# Altium schematic sheet Pmod_audio_in.SchDoc
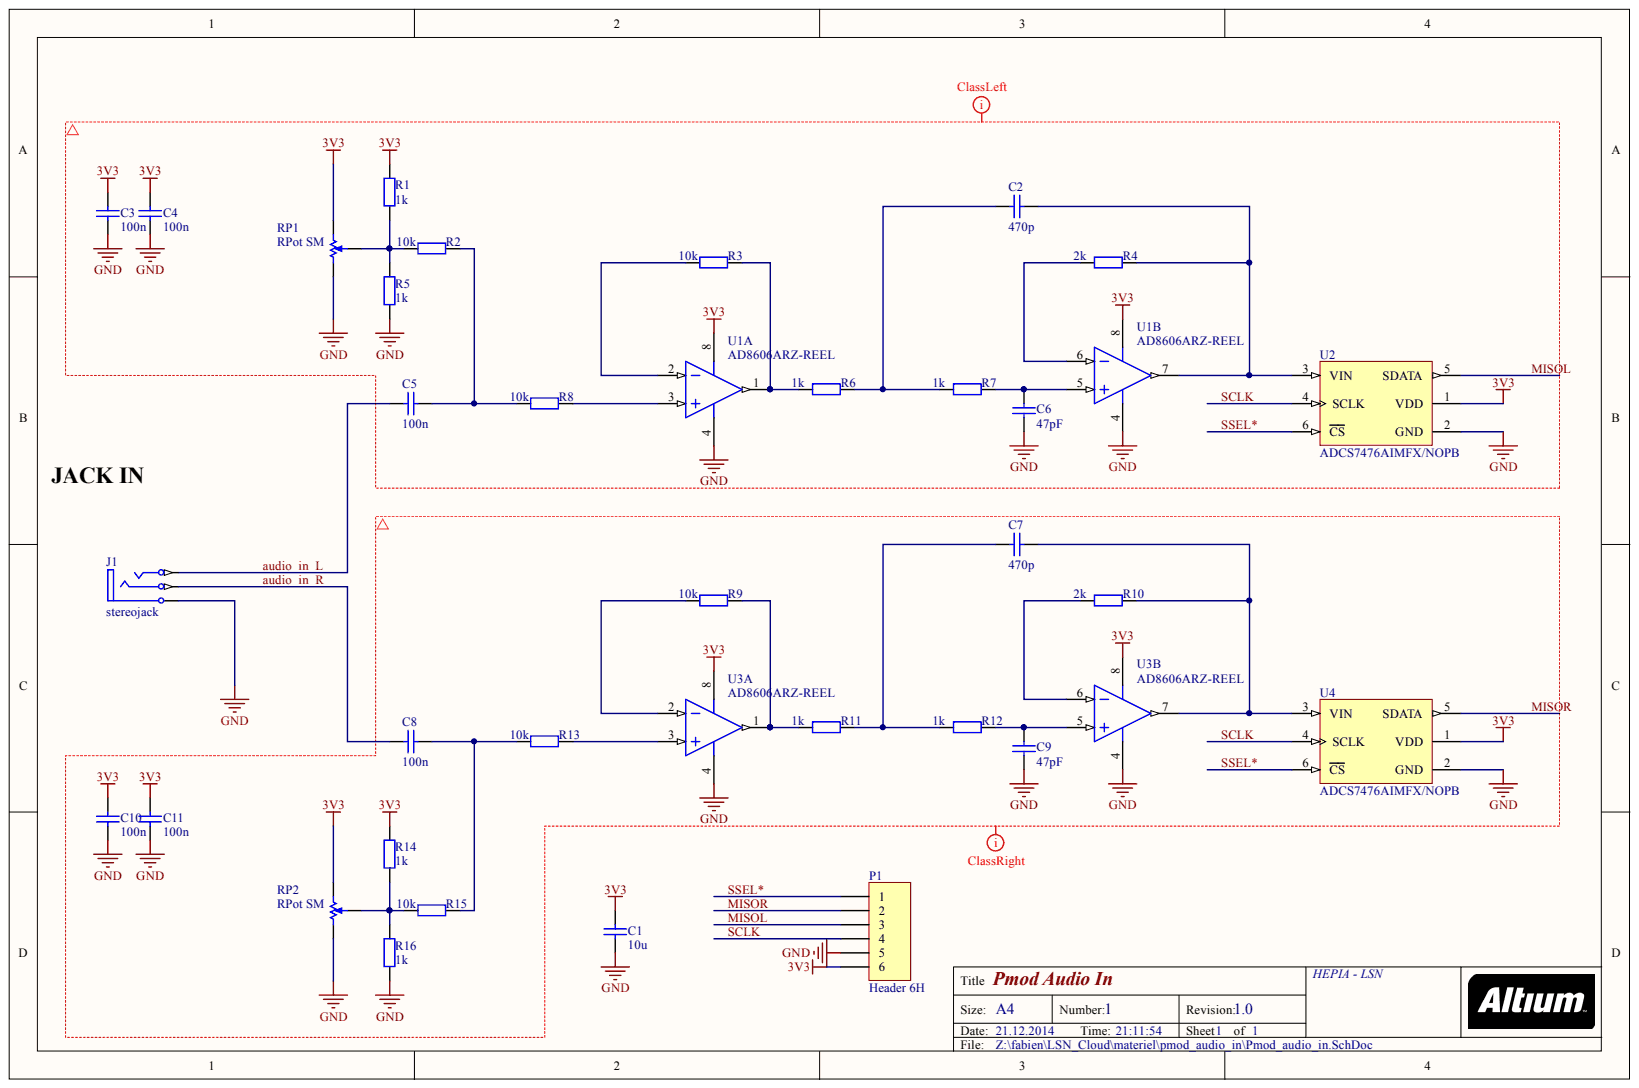
[diagram p0: Pmod_audio_in.SchDoc]

## Parts
C1  10u  [6-0805_M]
C2  470p  [1608[0603]]
C3  100n  [1608[0603]]
C4  100n  [1608[0603]]
C5  100n  [1608[0603]]
C6  47pF  [1608[0603]]
C7  470p  [1608[0603]]
C8  100n  [1608[0603]]
C9  47pF  [1608[0603]]
C10  100n  [1608[0603]]
C11  100n  [1608[0603]]
J1  stereojack  [JACK_3.5_STEREO_SMD]
P1  Header 6H  [HDR1X6H]
R1  1k  [1608[0603]]
R2  10k  [1608[0603]]
R3  10k  [1608[0603]]
R4  2k  [1608[0603]]
R5  1k  [1608[0603]]
R6  1k  [1608[0603]]
R7  1k  [1608[0603]]
R8  10k  [1608[0603]]
R9  10k  [1608[0603]]
R10  2k  [1608[0603]]
R11  1k  [1608[0603]]
R12  1k  [1608[0603]]
R13  10k  [1608[0603]]
R14  1k  [1608[0603]]
R15  10k  [1608[0603]]
R16  1k  [1608[0603]]
RP1  RPot SM  [POT4MM-2]
RP2  RPot SM  [POT4MM-2]
U1  AD8606ARZ-REEL  [ADI-R-8_N]  (parts A,B)
U2  ADCS7476AIMFX/NOPB  [MF06A_M]
U3  AD8606ARZ-REEL  [ADI-R-8_N]  (parts A,B)
U4  ADCS7476AIMFX/NOPB  [MF06A_M]

## Nets
3V3: C1.2 C3.2 C4.2 C10.2 C11.2 P1.6 R1.1 R14.1 RP1.CW RP2.CW U1.8 U2.1(VDD) U3.8 U4.1(VDD)
GND: C1.1 C3.1 C4.1 C6.1 C9.1 C10.1 C11.1 J1.2 P1.5 R5.2 R16.2 RP1.CCW RP2.CCW U1.4 U2.2(GND) U3.4 U4.2(GND)
AUDIO_IN_L: C5.1 J1.4
AUDIO_IN_R: C8.1 J1.3
MISOL: P1.3 U2.5(SDATA)
MISOR: P1.2 U4.5(SDATA)
SCLK: P1.4 U2.4(SCLK) U4.4(SCLK)
SSEL*: P1.1 U2.6(CS) U4.6(CS)
NetC2_1: C2.1 R6.1 R7.2
NetC2_2: C2.2 R4.1 U1.7 U2.3(VIN)
NetC5_2: C5.2 R2.1 R8.2
NetC6_2: C6.2 R7.1 U1.5
NetC7_1: C7.1 R11.1 R12.2
NetC7_2: C7.2 R10.1 U3.7 U4.3(VIN)
NetC8_2: C8.2 R13.2 R15.1
NetC9_2: C9.2 R12.1 U3.5
NetR1_2: R1.2 R2.2 R5.1 RP1.W
NetR3_1: R3.1 R6.2 U1.1
NetR3_2: R3.2 U1.2
NetR4_2: R4.2 U1.6
NetR8_1: R8.1 U1.3
NetR9_1: R9.1 R11.2 U3.1
NetR9_2: R9.2 U3.2
NetR10_2: R10.2 U3.6
NetR13_1: R13.1 U3.3
NetR14_2: R14.2 R15.2 R16.1 RP2.W
N00007:
N00008:

## Library data of the parts
C1-C11 = Cap Semi: Capacitor (Semiconductor SIM Model); Component Kind: Standard; LatestRevisionDate: 29-May-2009; LatestRevisionNote: IPC-7351 Footprint Added.; Library Name: Miscellaneous Devices.IntLib; PackageDescription: Chip Capacitor; PackageReference: 6-0805_M; Published: 8-Jun-2000; Signal Integrity: Cap Semi; Simulation: CAP; Publisher: Altium Limited
  C1: Value: 10u
  C2 C7: Value: 470p
  C3-C5 C8 C10 C11: Value: 100n
  C6 C9: Value: 47pF
J1 = Phonejack3: Jack Socket, 3-Conductor Open Circuit (Non-Normalling); DrillAndSlotSize: Corresponds to nominal value.; PackageDocument: 2003; PCB_Layout: Complies with manufacturer's recommendation.; Component Kind: Standard; ComponentLink1Description: Manufacturer Link; ComponentLink1URL: http://www.cliffuk.co.uk/; DatasheetDocument: 2003; LatestRevisionDate: 15-Jul-2004; LatestRevisionNote: Component Replaced.; Library Name: Miscellaneous Connectors.IntLib; PackageReference: S1VS_SSS; Published: 15-Jul-2004; Publisher: Altium Limited
P1 = Header 6H: Header, 6-Pin, Right Angle; Component Kind: Standard; LatestRevisionDate: 17-Jul-2002; LatestRevisionNote: Re-released for DXP Platform.; Library Name: Miscellaneous Connectors.IntLib; Signal Integrity: Connector; Publisher: Altium Limited
R1-R16 = Res2: Resistor; Component Kind: Standard; LatestRevisionDate: 17-Jul-2002; LatestRevisionNote: Re-released for DXP Platform.; Library Name: Miscellaneous Devices.IntLib; PackageDescription: Axial Device, Thru-Hole; 2 Leads; 0.4 in Pin Spacing; PackageReference: AXIAL-0.4; Published: 8-Jun-2000; Signal Integrity: Res; Simulation: RESISTOR; Publisher: Altium Limited
  R1 R5-R7 R11 R12 R14 R16: Value: 1k
  R2 R3 R8 R9 R13 R15: Value: 10k
  R4 R10: Value: 2k
RP1 RP2 = RPot SM: Square Trimming Potentiometer; Component Kind: Standard; ComponentLink1Description: Manufacturer Link; ComponentLink1URL: http://bourns.com/2/search.html; DatasheetVersion: Oct-2003; Library Name: Miscellaneous Devices.IntLib; PackageDescription: Square Trimming Potentiometer; PackageReference: POT4MM-2; Published: 17-Dec-2003; Publisher: Altium Limited
U1 U3 = CMP-0384-01320-1: Double Channel Wide Bandwidth Operational Amplifier, 10 MHz BW, 5 V/us SR, Industrial, 8-pin SOIC (R-8), Reel; Generic Part Number: AD8606; Manufacturer: Analog Devices; Amplifiers Per Package: 2; Input Bias Current: 200fA; Input Offset Voltage: 20uV; Iq/Amp: 1.2mA; Slew Rate: 5V/us; Small Signal Bandwidth: 10MHz; V Span Max: 6V; V Span Min: 2.7V; Code_JEDEC: MS-012-AA; Component Kind: Standard; ComponentLink1Description: Manufacturer URL; ComponentLink1URL: http://www.analog.com/en/index.html; ComponentLink2Description: Datasheet; ComponentLink2URL: http://www.analog.com/static/imported-files/data_sheets/AD8605_8606_8608.pdf; ComponentLink3Description: Package Specification; ComponentLink3URL: http://www.analog.com/static/imported-files/packages/PKG_PDF/SOIC_Narrow%20(R)/R_8.pdf; ComponentLink4Description: URL Link1; ComponentLink4URL: Reference Circuit:http://www.analog.com/en/all-operational-amplifiers-op-amps/operational-amplifiers-op-amps/ad8606/products/product.html#product-recommendations; DatasheetVersion: Rev. L; Library Name: Altium Content Vault; Mounting Technology: Surface Mount; PackageDescription: 8-Pin Standard Small Outline Package, Body 3.9 x 4.9 mm, Pitch 1.27 mm; PackageReference: R-8; PackageVersion: 060506-A; PartNumber: AD8606ARZ-REEL
U2 U4 = CMP-0054-00285-2: 1MSPS, 12-/10-/8-Bit A/D Converters in & LLP, 6-pin SOT-23, Pb-Free; Manufacturer: National Semiconductor; CompType: ADC IC; PackageTopMarking: X01A*XTT; PowerWise: N; Code_IPC: SOT26A-6; Code_JEDEC: MO-178-AB; Component Kind: Standard; ComponentLink1Description: ADCS7476 Datasheet; ComponentLink1URL: http://www.national.com/ds/AD/ADCS7476.pdf; ComponentLink2Description: ADCS7476 Web Page; ComponentLink2URL: http://www.national.com/pf/AD/ADCS7476.html; ComponentLink3Description: MF06A Package Page; ComponentLink3URL: http://www.national.com/packaging/folders/mf06a.html; DatasheetVersion: Feb-2010; Library Name: Altium Content Vault; PackageDescription: Molded SOT-23, .115 x .063 x .040in Body, 6 Lead, .0375in in Pitch; PackageReference: MF06A; PackageVersion: revC, Sep-2005; PinCount: 6; RevisionNote: Component URLs added; RoHS: Y
